# Altium schematic sheet COMMUNICATIONS.SchDoc(COMMUNICATIONS)
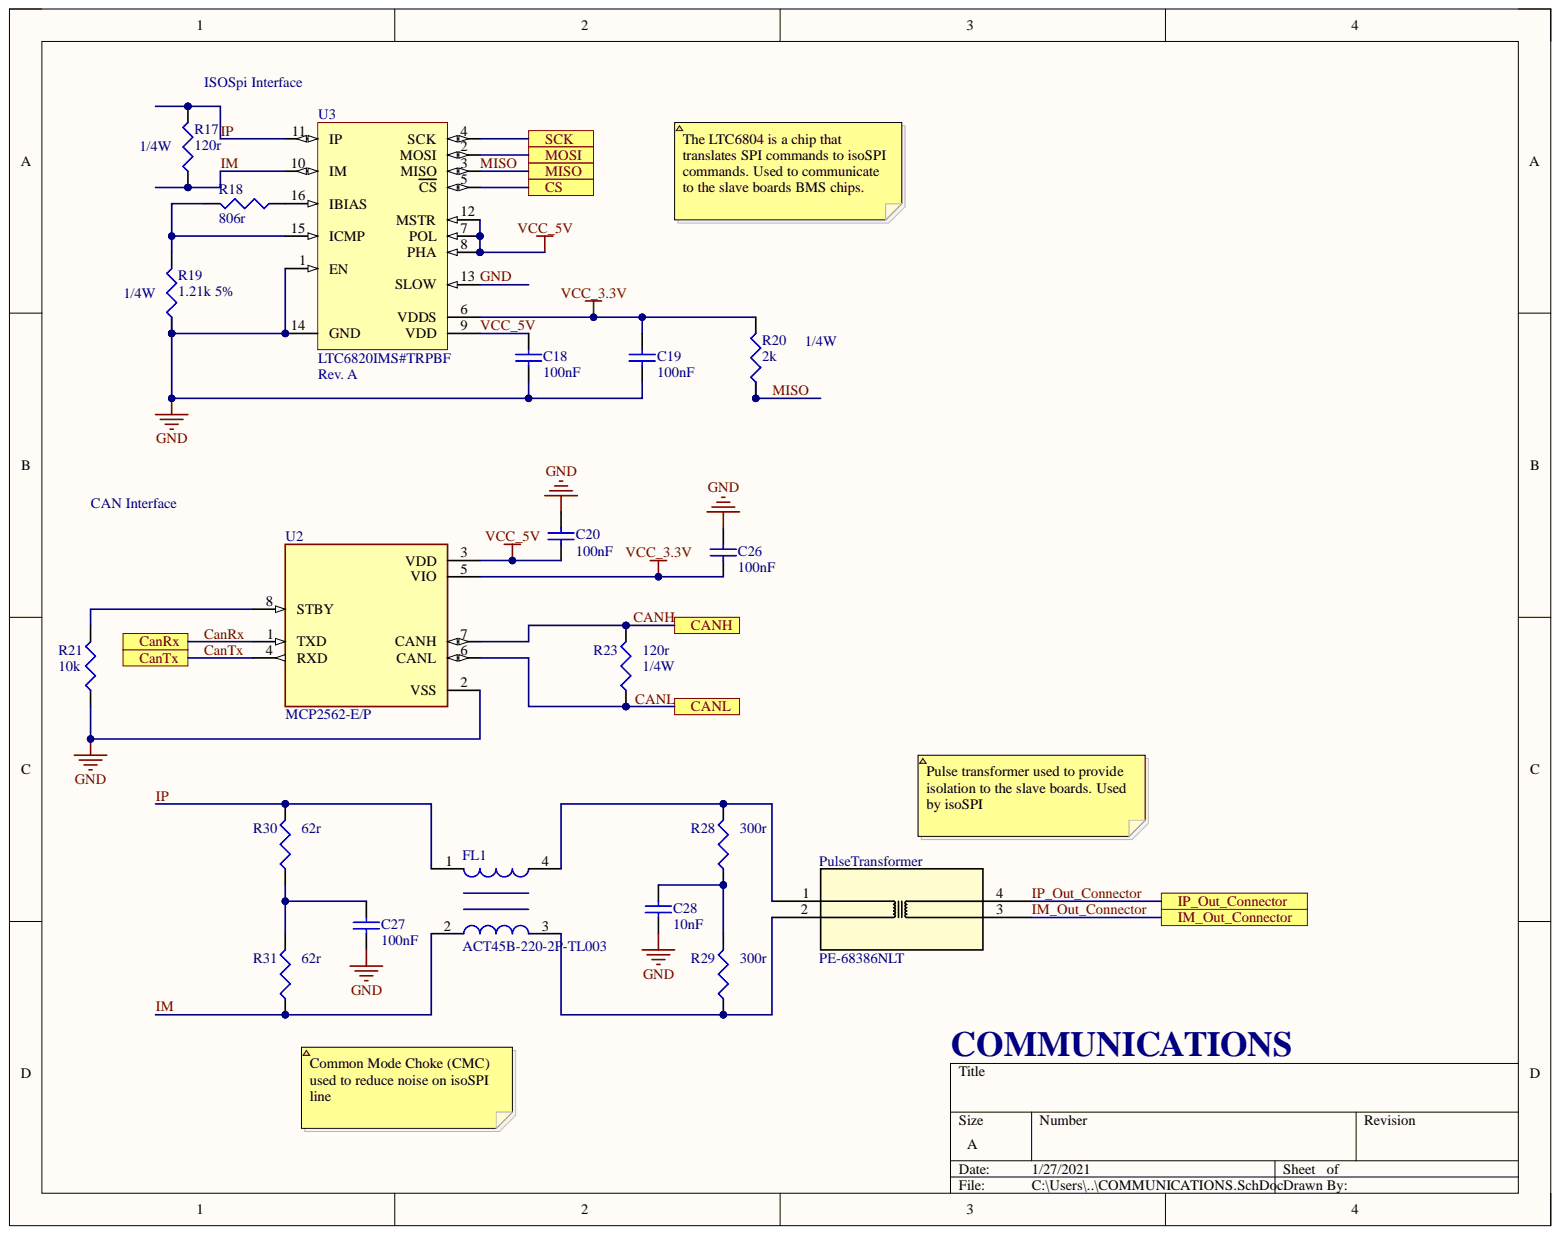
[diagram p0: COMMUNICATIONS.SchDoc]

## Parts
C18  100nF  [Cap, 5mm TH, Ceramic]
C19  100nF  [Cap, 5mm TH, Ceramic]
C20  100nF  [Cap, 5mm TH, Ceramic]
C26  100nF  [Cap, 5mm TH, Ceramic]
C27  100nF  [Cap, 5mm TH, Ceramic]
C28  10nF  [Cap, 5mm TH, Ceramic]
FL1  ACT45B-220-2P-TL003  [FIL_ACT45B-220-2P-TL003]
PulseTransformer  PE-68386NLT  [PE-68386NL_PUL]
R17  120r  [RESAD640W45L370D200 - duplicate]
R18  806r  [RESAD640W45L370D200 - duplicate]
R19  1.21k 5%  [RESAD640W45L370D200 - duplicate]
R20  2k  [RESAD640W45L370D200 - duplicate]
R21  10k  [RESAD640W45L370D200 - duplicate]
R23  120r  [RESAD640W45L370D200 - duplicate]
R28  300r  [RESAD640W45L370D200 - duplicate]
R29  300r  [RESAD640W45L370D200 - duplicate]
R30  62r  [RESAD640W45L370D200 - duplicate]
R31  62r  [RESAD640W45L370D200 - duplicate]
U2  MCP2562-E/P  [DIP787W45P254L1016H533Q8]
U3  LTC6820IMS#TRPBF  DatasheetVersion: Rev. A  [LT-MS-16_M]

## Ports
CANH, CANL, CanRx, CanTx, CS, IM_Out_Connector, IP_Out_Connector, MISO, MOSI, SCK

## Nets
VCC_3.3V: C19.2 C26.2 R20.2 U2.5(VIO) U3.6(VDDS)
CANH: R23.2 U2.7(CANH) ; port CANH
CANL: R23.1 U2.6(CANL) ; port CANL
CanRx: U2.1(TXD) ; port CanRx
CanTx: U2.4(RXD) ; port CanTx
CS: U3.5(CS) ; port CS
GND: C18.1 C19.1 C20.1 C26.1 C27.2 C28.2 R19.1 R21.1 U2.2(VSS) U3.1(EN) U3.13(SLOW) U3.14(GND)
IM: FL1.2 R17.1 R31.1 U3.10(IM)
IM_Out_Connector: PulseTransformer.3 ; port IM_Out_Connector
IP: FL1.1 R17.2 R30.2 U3.11(IP)
IP_Out_Connector: PulseTransformer.4 ; port IP_Out_Connector
MISO: R20.1 U3.3(MISO) ; port MISO
MOSI: U3.2(MOSI) ; port MOSI
SCK: U3.4(SCK) ; port SCK
VCC_5V: C18.2 C20.2 U2.3(VDD) U3.7(POL) U3.8(PHA) U3.9(VDD) U3.12(MSTR)
NetC27_1: C27.1 R30.1 R31.2
NetC28_1: C28.1 R28.1 R29.2
NetFL1_3: FL1.3 PulseTransformer.2 R29.1
NetFL1_4: FL1.4 PulseTransformer.1 R28.2
NetR18_1: R18.1 U3.16(IBIAS)
NetR18_2: R18.2 R19.2 U3.15(ICMP)
NetR21_2: R21.2 U2.8(STBY)

## Library data of the parts
C18-C20 C26-C28 = Cap, 5MM TH, Ceramic: Generic Cap, 5MM TH, Ceramic; TargetLibrary: RITRacing-Passives; Value: Value?; Component Kind: Standard; Library Name: Passives.IntLib; Published: 22Oct2016; Status: Active; Publisher: T.Tallo
FL1 = ACT45B-220-2P-TL003: 100ÂµH @ 100kHz 2 Line Common Mode Choke Surface Mount 5.8 kOhms @ 10MHz 150mA DCR 2Ohm; Availability: Unavailable; Component Kind: Standard; MP: ACT45B-220-2P-TL003; Package: None; Price: None; Library Name: BMS_MasterboardUpdated_1.SCHLIB
PulseTransformer = PE-68386NLT: No Description Available; Built_By: EMA_Cory; SourceLibrary: Pulse_Electronics_2019-12-18; Vendor: Pulse Electronics Corporation; Component Kind: Standard; Manufacturer_Part_Number: PE-68386NLT; RefDes: RefDes; Type: DEV; Copyright: Copyright (C) 2018 Accelerated Designs. All rights reserved; Library Name: BMS_MasterboardUpdated.SCHLIB
R17-R21 R23 R28-R31 = Resistor, TH: Generic TH Resistor; TargetLibrary: RITRacing-Passives; Value: Value?; Component Kind: Standard; Library Name: Passives.IntLib; Published: 22Oct2016; Status: Active; Publisher: T.Tallo
U2 = MCP2562-E/P: 1/1 Transceiver Half CANbus 8-PDIP; MF: Microchip; Component Kind: Standard; Digi-Key_Part_Number: MCP2562-E/P-ND; DigiKey-Purchase-URL: https://snapeda.com/shop?store=DigiKey&id=290285; MP: MCP2562-E/P; Package: DIP-8 Microchip; SnapEDA_Link: https://www.snapeda.com/parts/MCP2562-E/P/Microchip/view-part/290285/?ref=snap; Library Name: BMS_MasterboardUpdated_1.SCHLIB
U3 = CMP-0937-00011-1: IsoSPI Isolated Communication Interface, 2.7 to 5.5 V, -40 to 85 degC, 16-Pin MSOP (MS), Pb-Free, Tape and Reel; Manufacturer: Analog Devices / Linear Technology; IO Supply Current: 1 uA Max.; IO Supply Voltage Range: 1.7 to 5.5 V; Receiver Input Resistance: 35 kOhm; Supply Current, IDLE State: 2 uA; VBIAS: 1.9 to 2.1 V; Component Kind: Standard; ComponentLink1Description: Manufacturer URL; ComponentLink1URL: http://www.linear.com/; ComponentLink2Description: Datasheet; ComponentLink2URL: http://cds.linear.com/docs/en/datasheet/6820fa.pdf; ComponentLink3Description: Package Specification; ComponentLink3URL: http://cds.linear.com/docs/en/packaging/05081669_A_MS16.pdf; Manufacturer Part Number: LTC6820IMS#TRPBF; Mounting Technology: Surface Mount; PackageDescription: 16-Pin Micro Small Outline Package, Body 4.039 x 3 mm, Pitch 0.5 mm; PackageReference: MS-16; PackageVersion: Rev. A; Packing: Tape and Reel; PartNumber: LTC6820IMS#TRPBF; Power Supply: 2.7 to 5.5 V; Temperature Range (degC): -40 to 85; Library Name: BMS_MasterboardUpdated.SCHLIB
